# Altium schematic sheet 419-005-01-02_PG 17 - Expansion B2B.SchDoc("419-005-01-02_PG 17 - Expansion B2B")
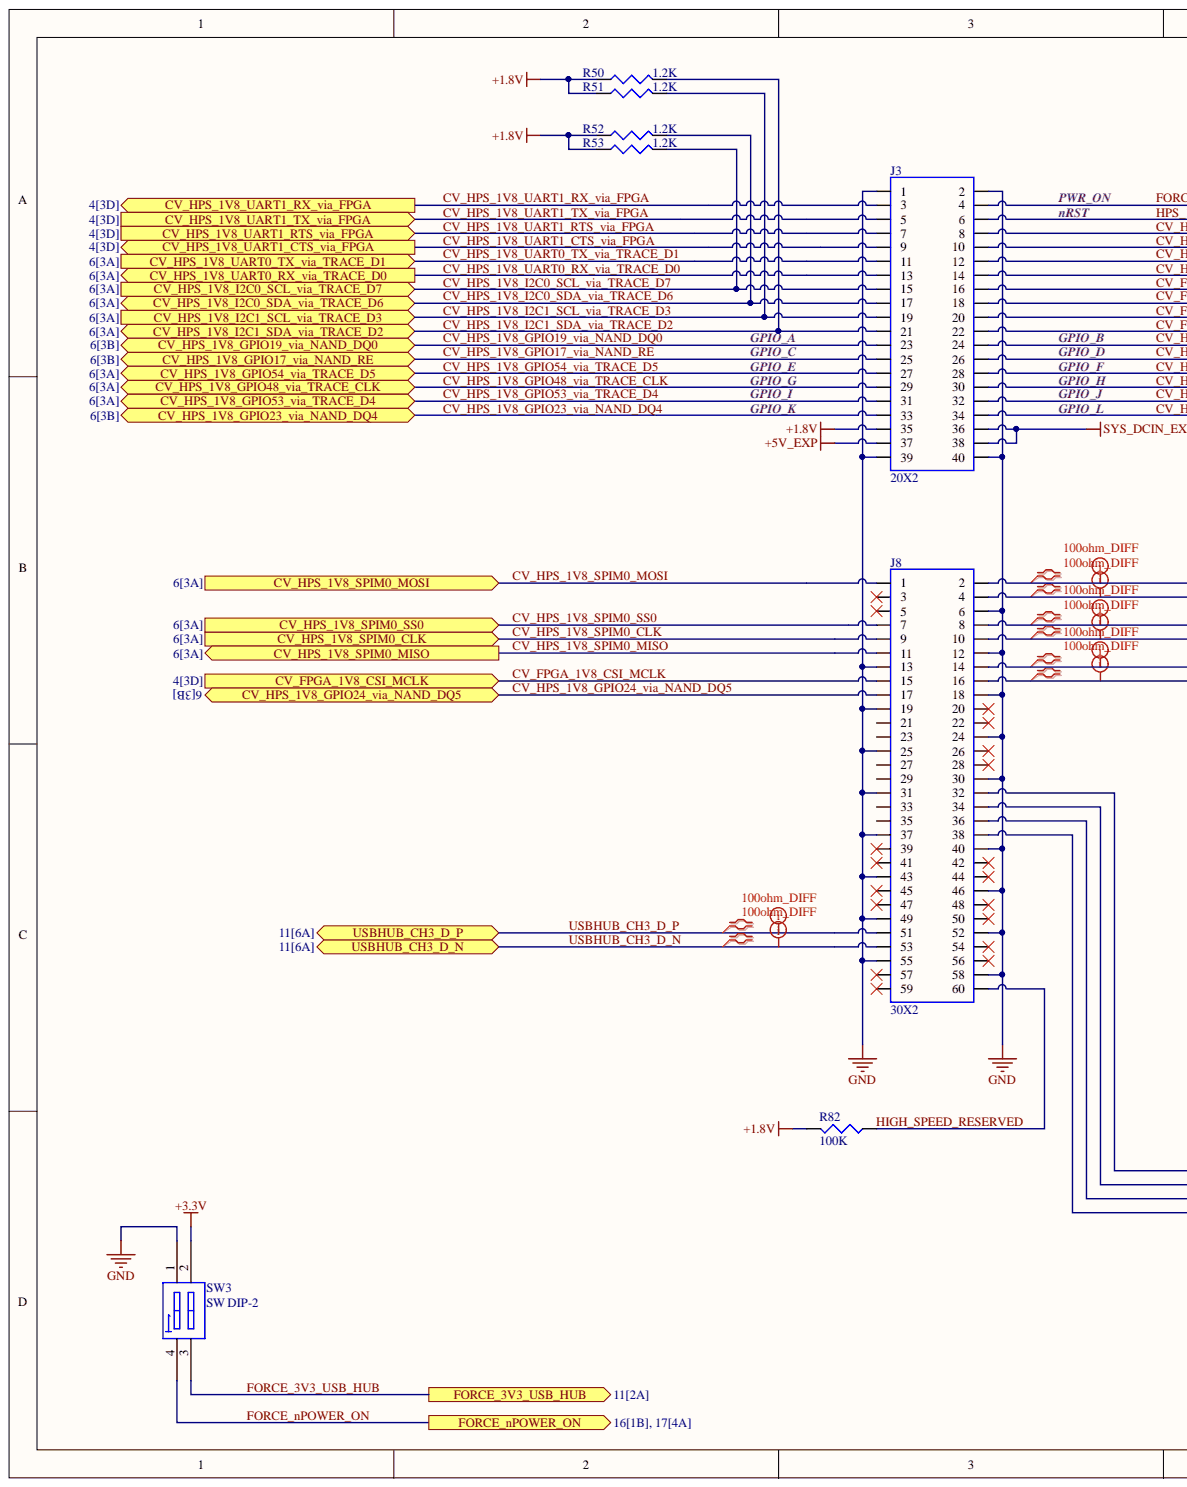
[diagram p0: 419-005-01-02_PG 17 - Expansion B2B.SchDoc, left]
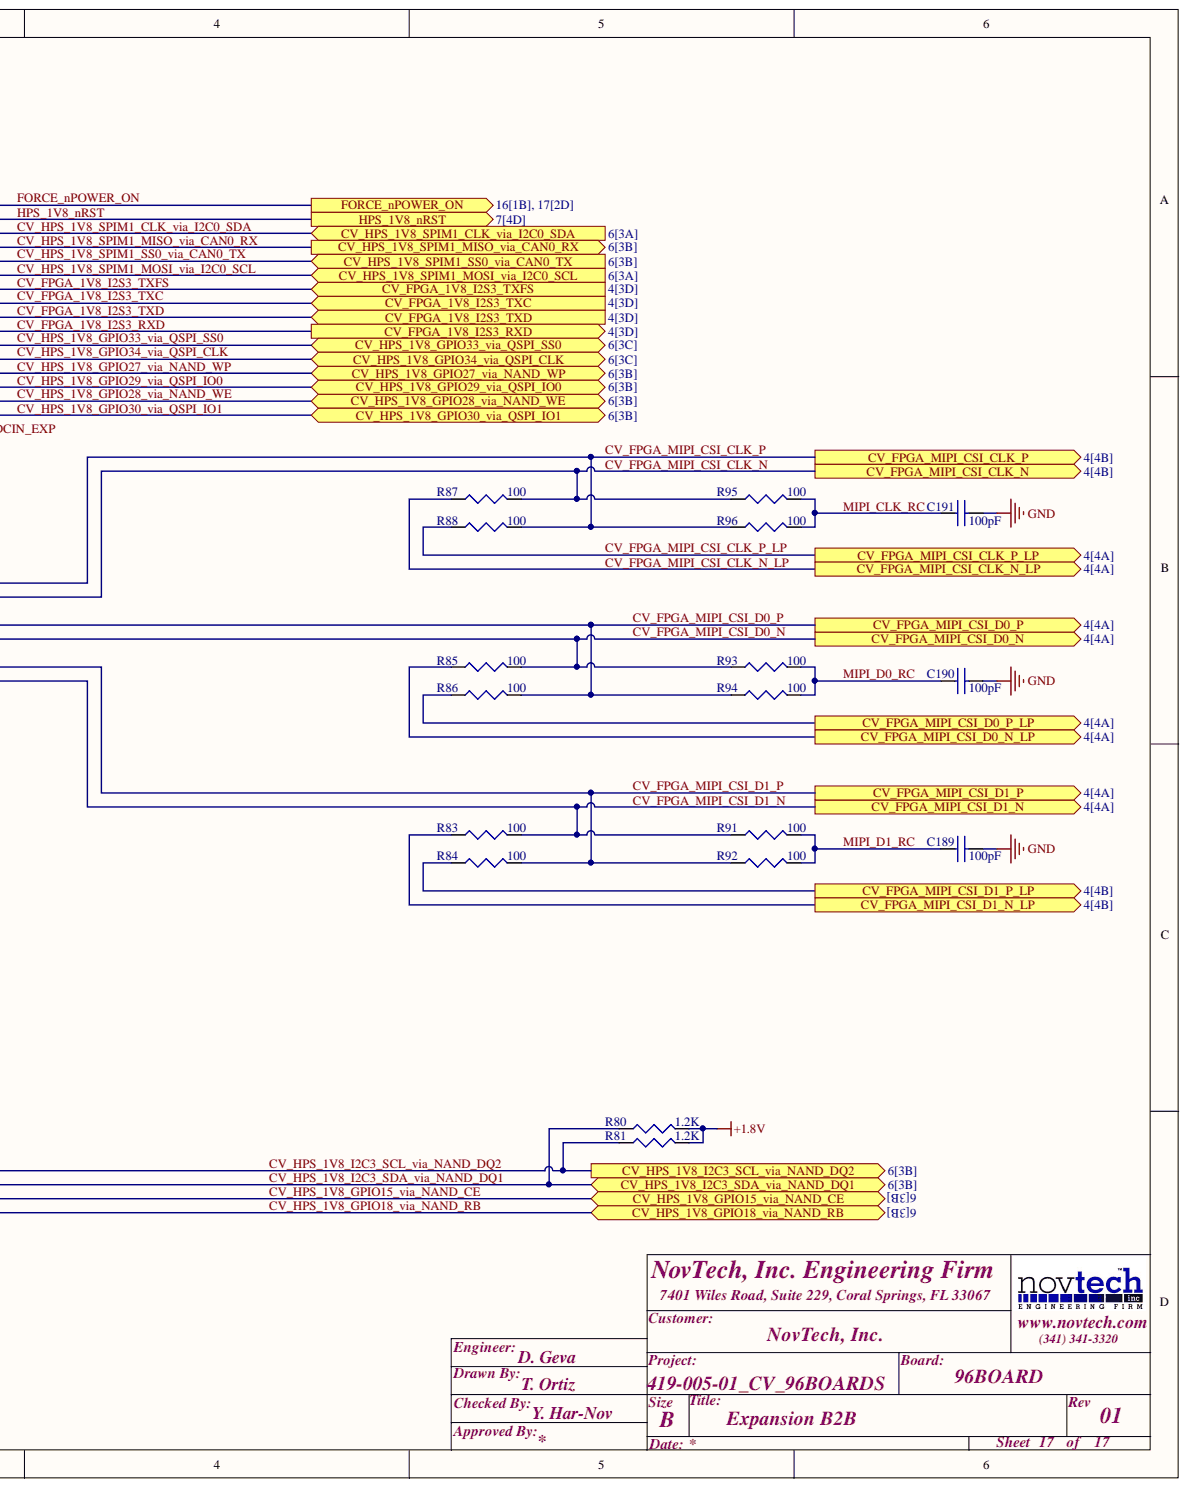
[diagram p1: 419-005-01-02_PG 17 - Expansion B2B.SchDoc, right]

## Parts
C189  100pF  [0201_C]
C190  100pF  [0201_C]
C191  100pF  [0201_C]
J3  20X2  [BH20X2_2MM_SOCKET - 96BOARDSs - SMT]
J8  30X2  [61082_061409LF]
R50  1.2K  [0201_R]
R51  1.2K  [0201_R]
R52  1.2K  [0201_R]
R53  1.2K  [0201_R]
R80  1.2K  [0201_R]
R81  1.2K  [0201_R]
R82  100K  [0201_R]
R83  100  [0201_R]
R84  100  [0201_R]
R85  100  [0201_R]
R86  100  [0201_R]
R87  100  [0201_R]
R88  100  [0201_R]
R91  100  [0201_R]
R92  100  [0201_R]
R93  100  [0201_R]
R94  100  [0201_R]
R95  100  [0201_R]
R96  100  [0201_R]
SW3  SW DIP-2  [218-02 DIP SW]

## Ports
CV_FPGA_1V8_CSI_MCLK, CV_FPGA_1V8_I2S3_RXD, CV_FPGA_1V8_I2S3_TXC, CV_FPGA_1V8_I2S3_TXD, CV_FPGA_1V8_I2S3_TXFS, CV_FPGA_MIPI_CSI_CLK_N, CV_FPGA_MIPI_CSI_CLK_N_LP, CV_FPGA_MIPI_CSI_CLK_P, CV_FPGA_MIPI_CSI_CLK_P_LP, CV_FPGA_MIPI_CSI_D0_N, CV_FPGA_MIPI_CSI_D0_N_LP, CV_FPGA_MIPI_CSI_D0_P, CV_FPGA_MIPI_CSI_D0_P_LP, CV_FPGA_MIPI_CSI_D1_N, CV_FPGA_MIPI_CSI_D1_N_LP, CV_FPGA_MIPI_CSI_D1_P, CV_FPGA_MIPI_CSI_D1_P_LP, CV_HPS_1V8_GPIO15_VIA_NAND_CE, CV_HPS_1V8_GPIO17_VIA_NAND_RE, CV_HPS_1V8_GPIO18_VIA_NAND_RB, CV_HPS_1V8_GPIO19_VIA_NAND_DQ0, CV_HPS_1V8_GPIO23_VIA_NAND_DQ4, CV_HPS_1V8_GPIO24_VIA_NAND_DQ5, CV_HPS_1V8_GPIO27_VIA_NAND_WP, CV_HPS_1V8_GPIO28_VIA_NAND_WE, CV_HPS_1V8_GPIO29_VIA_QSPI_IO0, CV_HPS_1V8_GPIO30_VIA_QSPI_IO1, CV_HPS_1V8_GPIO33_VIA_QSPI_SS0, CV_HPS_1V8_GPIO34_VIA_QSPI_CLK, CV_HPS_1V8_GPIO48_VIA_TRACE_CLK, CV_HPS_1V8_GPIO53_VIA_TRACE_D4, CV_HPS_1V8_GPIO54_VIA_TRACE_D5, CV_HPS_1V8_I2C0_SCL_VIA_TRACE_D7, CV_HPS_1V8_I2C0_SDA_VIA_TRACE_D6, CV_HPS_1V8_I2C1_SCL_VIA_TRACE_D3, CV_HPS_1V8_I2C1_SDA_VIA_TRACE_D2, CV_HPS_1V8_I2C3_SCL_VIA_NAND_DQ2, CV_HPS_1V8_I2C3_SDA_VIA_NAND_DQ1, CV_HPS_1V8_SPIM0_CLK, CV_HPS_1V8_SPIM0_MISO, CV_HPS_1V8_SPIM0_MOSI, CV_HPS_1V8_SPIM0_SS0, CV_HPS_1V8_SPIM1_CLK_VIA_I2C0_SDA, CV_HPS_1V8_SPIM1_MISO_VIA_CAN0_RX, CV_HPS_1V8_SPIM1_MOSI_VIA_I2C0_SCL, CV_HPS_1V8_SPIM1_SS0_VIA_CAN0_TX, CV_HPS_1V8_UART0_RX_VIA_TRACE_D0, CV_HPS_1V8_UART0_TX_VIA_TRACE_D1, CV_HPS_1V8_UART1_CTS_VIA_FPGA, CV_HPS_1V8_UART1_RTS_VIA_FPGA, CV_HPS_1V8_UART1_RX_VIA_FPGA, CV_HPS_1V8_UART1_TX_VIA_FPGA, FORCE_3V3_USB_HUB, FORCE_NPOWER_ON, HPS_1V8_NRST, USBHUB_CH3_D_N, USBHUB_CH3_D_P

## Nets
+1.8V: J3.35 R50.1 R51.1 R52.1 R53.1 R80.2 R81.2 R82.2
+3.3V: SW3.2
+5V_EXP: J3.37
GND: C189.2 C190.2 C191.2 J3.1 J3.2 J3.39 J3.40 J8.6 J8.12 J8.13 J8.18 J8.19 J8.24 J8.25 J8.30 J8.31 J8.37 J8.40 J8.43 J8.46 J8.49 J8.52 J8.55 J8.58 SW3.1
SYS_DCIN_EXP: J3.36 J3.38
CV_FPGA_1V8_CSI_MCLK: J8.15 ; port CV_FPGA_1V8_CSI_MCLK
CV_FPGA_1V8_I2S3_RXD: J3.22 ; port CV_FPGA_1V8_I2S3_RXD
CV_FPGA_1V8_I2S3_TXC: J3.18 ; port CV_FPGA_1V8_I2S3_TXC
CV_FPGA_1V8_I2S3_TXD: J3.20 ; port CV_FPGA_1V8_I2S3_TXD
CV_FPGA_1V8_I2S3_TXFS: J3.16 ; port CV_FPGA_1V8_I2S3_TXFS
CV_FPGA_MIPI_CSI_CLK_N: J8.4 R87.2 R95.1 ; port CV_FPGA_MIPI_CSI_CLK_N
CV_FPGA_MIPI_CSI_CLK_N_LP: R87.1 ; port CV_FPGA_MIPI_CSI_CLK_N_LP
CV_FPGA_MIPI_CSI_CLK_P: J8.2 R88.2 R96.1 ; port CV_FPGA_MIPI_CSI_CLK_P
CV_FPGA_MIPI_CSI_CLK_P_LP: R88.1 ; port CV_FPGA_MIPI_CSI_CLK_P_LP
CV_FPGA_MIPI_CSI_D0_N: J8.10 R85.2 R93.1 ; port CV_FPGA_MIPI_CSI_D0_N
CV_FPGA_MIPI_CSI_D0_N_LP: R85.1 ; port CV_FPGA_MIPI_CSI_D0_N_LP
CV_FPGA_MIPI_CSI_D0_P: J8.8 R86.2 R94.1 ; port CV_FPGA_MIPI_CSI_D0_P
CV_FPGA_MIPI_CSI_D0_P_LP: R86.1 ; port CV_FPGA_MIPI_CSI_D0_P_LP
CV_FPGA_MIPI_CSI_D1_N: J8.16 R83.2 R91.1 ; port CV_FPGA_MIPI_CSI_D1_N
CV_FPGA_MIPI_CSI_D1_N_LP: R83.1 ; port CV_FPGA_MIPI_CSI_D1_N_LP
CV_FPGA_MIPI_CSI_D1_P: J8.14 R84.2 R92.1 ; port CV_FPGA_MIPI_CSI_D1_P
CV_FPGA_MIPI_CSI_D1_P_LP: R84.1 ; port CV_FPGA_MIPI_CSI_D1_P_LP
CV_HPS_1V8_GPIO15_VIA_NAND_CE: J8.36 ; port CV_HPS_1V8_GPIO15_VIA_NAND_CE
CV_HPS_1V8_GPIO17_VIA_NAND_RE: J3.25 ; port CV_HPS_1V8_GPIO17_VIA_NAND_RE
CV_HPS_1V8_GPIO18_VIA_NAND_RB: J8.38 ; port CV_HPS_1V8_GPIO18_VIA_NAND_RB
CV_HPS_1V8_GPIO19_VIA_NAND_DQ0: J3.23 ; port CV_HPS_1V8_GPIO19_VIA_NAND_DQ0
CV_HPS_1V8_GPIO23_VIA_NAND_DQ4: J3.33 ; port CV_HPS_1V8_GPIO23_VIA_NAND_DQ4
CV_HPS_1V8_GPIO24_VIA_NAND_DQ5: J8.17 ; port CV_HPS_1V8_GPIO24_VIA_NAND_DQ5
CV_HPS_1V8_GPIO27_VIA_NAND_WP: J3.28 ; port CV_HPS_1V8_GPIO27_VIA_NAND_WP
CV_HPS_1V8_GPIO28_VIA_NAND_WE: J3.32 ; port CV_HPS_1V8_GPIO28_VIA_NAND_WE
CV_HPS_1V8_GPIO29_VIA_QSPI_IO0: J3.30 ; port CV_HPS_1V8_GPIO29_VIA_QSPI_IO0
CV_HPS_1V8_GPIO30_VIA_QSPI_IO1: J3.34 ; port CV_HPS_1V8_GPIO30_VIA_QSPI_IO1
CV_HPS_1V8_GPIO33_VIA_QSPI_SS0: J3.24 ; port CV_HPS_1V8_GPIO33_VIA_QSPI_SS0
CV_HPS_1V8_GPIO34_VIA_QSPI_CLK: J3.26 ; port CV_HPS_1V8_GPIO34_VIA_QSPI_CLK
CV_HPS_1V8_GPIO48_VIA_TRACE_CLK: J3.29 ; port CV_HPS_1V8_GPIO48_VIA_TRACE_CLK
CV_HPS_1V8_GPIO53_VIA_TRACE_D4: J3.31 ; port CV_HPS_1V8_GPIO53_VIA_TRACE_D4
CV_HPS_1V8_GPIO54_VIA_TRACE_D5: J3.27 ; port CV_HPS_1V8_GPIO54_VIA_TRACE_D5
CV_HPS_1V8_I2C0_SCL_VIA_TRACE_D7: J3.15 R53.2 ; port CV_HPS_1V8_I2C0_SCL_VIA_TRACE_D7
CV_HPS_1V8_I2C0_SDA_VIA_TRACE_D6: J3.17 R52.2 ; port CV_HPS_1V8_I2C0_SDA_VIA_TRACE_D6
CV_HPS_1V8_I2C1_SCL_VIA_TRACE_D3: J3.19 R51.2 ; port CV_HPS_1V8_I2C1_SCL_VIA_TRACE_D3
CV_HPS_1V8_I2C1_SDA_VIA_TRACE_D2: J3.21 R50.2 ; port CV_HPS_1V8_I2C1_SDA_VIA_TRACE_D2
CV_HPS_1V8_I2C3_SCL_VIA_NAND_DQ2: J8.32 R81.1 ; port CV_HPS_1V8_I2C3_SCL_VIA_NAND_DQ2
CV_HPS_1V8_I2C3_SDA_VIA_NAND_DQ1: J8.34 R80.1 ; port CV_HPS_1V8_I2C3_SDA_VIA_NAND_DQ1
CV_HPS_1V8_SPIM0_CLK: J8.9 ; port CV_HPS_1V8_SPIM0_CLK
CV_HPS_1V8_SPIM0_MISO: J8.11 ; port CV_HPS_1V8_SPIM0_MISO
CV_HPS_1V8_SPIM0_MOSI: J8.1 ; port CV_HPS_1V8_SPIM0_MOSI
CV_HPS_1V8_SPIM0_SS0: J8.7 ; port CV_HPS_1V8_SPIM0_SS0
CV_HPS_1V8_SPIM1_CLK_VIA_I2C0_SDA: J3.8 ; port CV_HPS_1V8_SPIM1_CLK_VIA_I2C0_SDA
CV_HPS_1V8_SPIM1_MISO_VIA_CAN0_RX: J3.10 ; port CV_HPS_1V8_SPIM1_MISO_VIA_CAN0_RX
CV_HPS_1V8_SPIM1_MOSI_VIA_I2C0_SCL: J3.14 ; port CV_HPS_1V8_SPIM1_MOSI_VIA_I2C0_SCL
CV_HPS_1V8_SPIM1_SS0_VIA_CAN0_TX: J3.12 ; port CV_HPS_1V8_SPIM1_SS0_VIA_CAN0_TX
CV_HPS_1V8_UART0_RX_VIA_TRACE_D0: J3.13 ; port CV_HPS_1V8_UART0_RX_VIA_TRACE_D0
CV_HPS_1V8_UART0_TX_VIA_TRACE_D1: J3.11 ; port CV_HPS_1V8_UART0_TX_VIA_TRACE_D1
CV_HPS_1V8_UART1_CTS_VIA_FPGA: J3.9 ; port CV_HPS_1V8_UART1_CTS_VIA_FPGA
CV_HPS_1V8_UART1_RTS_VIA_FPGA: J3.7 ; port CV_HPS_1V8_UART1_RTS_VIA_FPGA
CV_HPS_1V8_UART1_RX_VIA_FPGA: J3.3 ; port CV_HPS_1V8_UART1_RX_VIA_FPGA
CV_HPS_1V8_UART1_TX_VIA_FPGA: J3.5 ; port CV_HPS_1V8_UART1_TX_VIA_FPGA
FORCE_3V3_USB_HUB: SW3.3 ; port FORCE_3V3_USB_HUB
FORCE_NPOWER_ON: J3.4 SW3.4 ; port FORCE_NPOWER_ON
HIGH_SPEED_RESERVED: J8.60 R82.1
HPS_1V8_NRST: J3.6 ; port HPS_1V8_NRST
MIPI_CLK_RC: C191.1 R95.2 R96.2
MIPI_D0_RC: C190.1 R93.2 R94.2
MIPI_D1_RC: C189.1 R91.2 R92.2
USBHUB_CH3_D_N: J8.53 ; port USBHUB_CH3_D_N
USBHUB_CH3_D_P: J8.51 ; port USBHUB_CH3_D_P

Not connected: J8 3 5 20 21 22 23 26 27 28 29 33 35 39 41 42 44 45 47 48 50 54 56 57 59

## Library data of the parts
C189-C191 = CAP_0201: Capasitor 0201 footprint; PART: GRM0335C1E101JA01D; MFG: Murata; TEMP: -55°C to +125°C; TYPE: SMT; Component Kind: Standard; DigiKey: 490-3160-1-ND; Library Name: PASSIVES.SchLib; RoHS: Yes
J3 = HEADER_20X2: Component Kind: Standard; Library Name: CONNECTORS_MECHANICAL.SchLib
J8 = HEADER_30X2: Component Kind: Standard; Library Name: CONNECTORS_MECHANICAL.SchLib
R50-R53 R80 R81 = RESISOTR 0201: Resistor 0201 footprint; PART: ERJ-1GEF1201C; MFG: Panasonic; Component Kind: Standard; Digikey: P1.20KABCT-ND; Library Name: PASSIVES.SchLib; RoHS: Yes; TYPE: SMT
R82 = RESISOTR 0201: Resistor 0201 footprint; MFG: Panasonic; Component Kind: Standard; Library Name: PASSIVES.SchLib; RoHS: Yes; TYPE: SMT
R83-R88 = RESISOTR 0201: Resistor 0201 footprint; PART: RMCF0201FT100R; MFG: Stackpole; TEMP: -55°C t0 +125°C; Component Kind: Standard; DigiKey: RMCF0201FT100RCT-ND; Library Name: PASSIVES.SchLib; RoHS: Yes; TYPE: SMT
R91-R96 = RESISOTR 0201: Resistor 0201 footprint; PART: RMCF0201FT150R; MFG: Stackpole; TEMP: -55°C t0 +125°C; Component Kind: Standard; DigiKey: RMCF0201FT150RCT-ND; Library Name: PASSIVES.SchLib; RoHS: Yes; TYPE: SMT
SW3 = SW DIP-2: PART: 218-2LPSTR; MFG: CTS; Component Kind: Standard; Digikey: CT3108CT-ND; Library Name: CONNECTORS_MECHANICAL.SchLib; RoHS: Yes; TYPE: SMT
